# Altium schematic sheet 1541 Interface for ZX-81.SchDoc("1541 Interface for ZX-81")
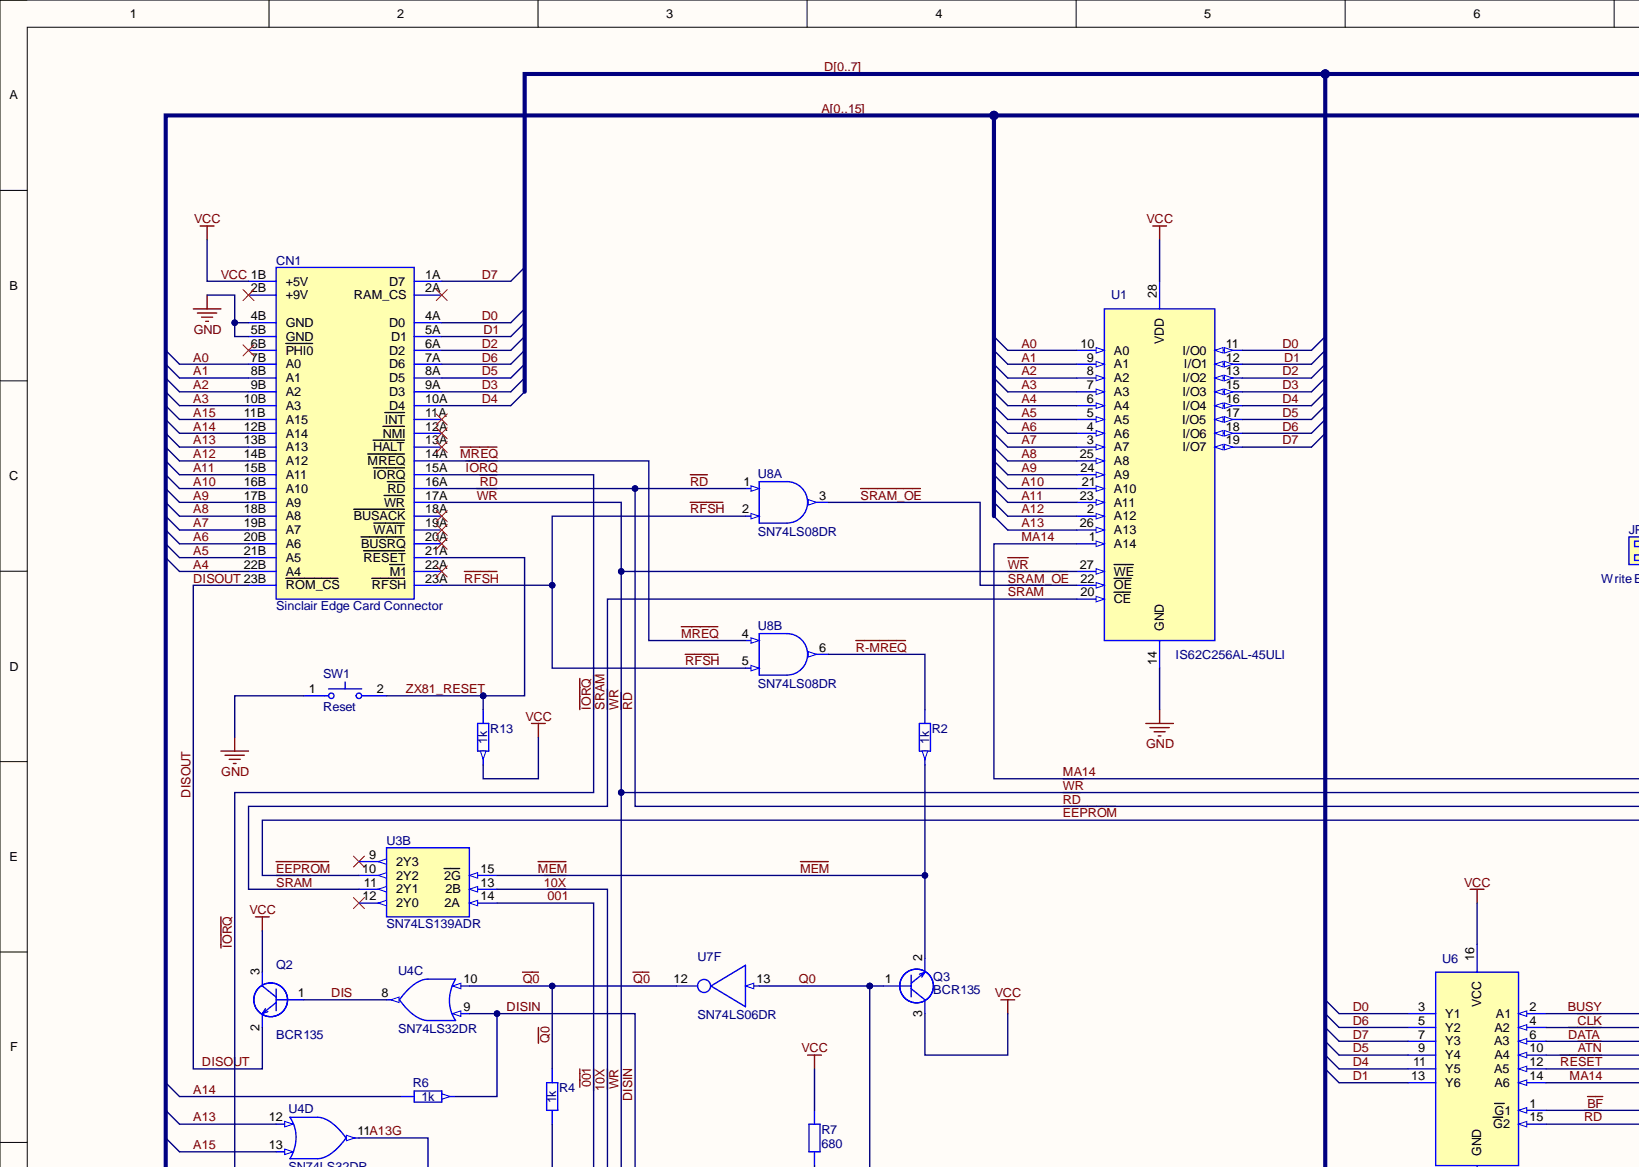
[diagram p0: 1541 Interface for ZX-81.SchDoc, top left]
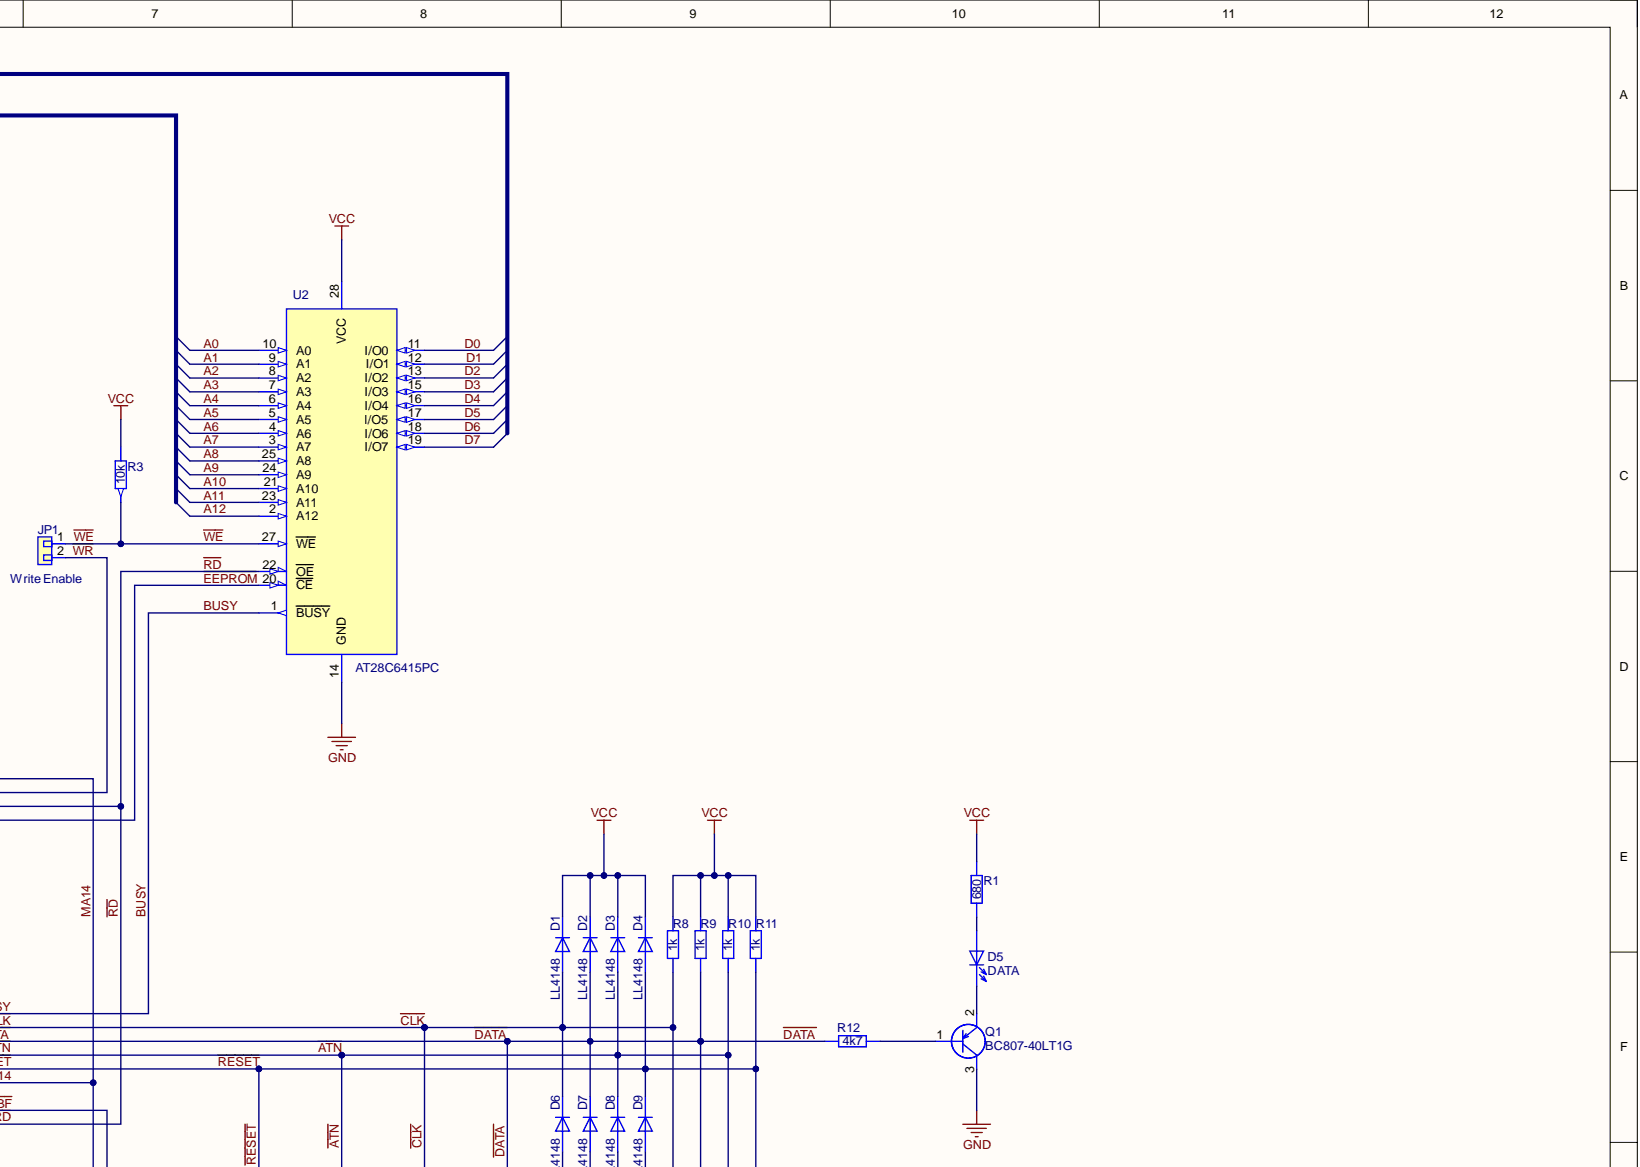
[diagram p1: 1541 Interface for ZX-81.SchDoc, top right]
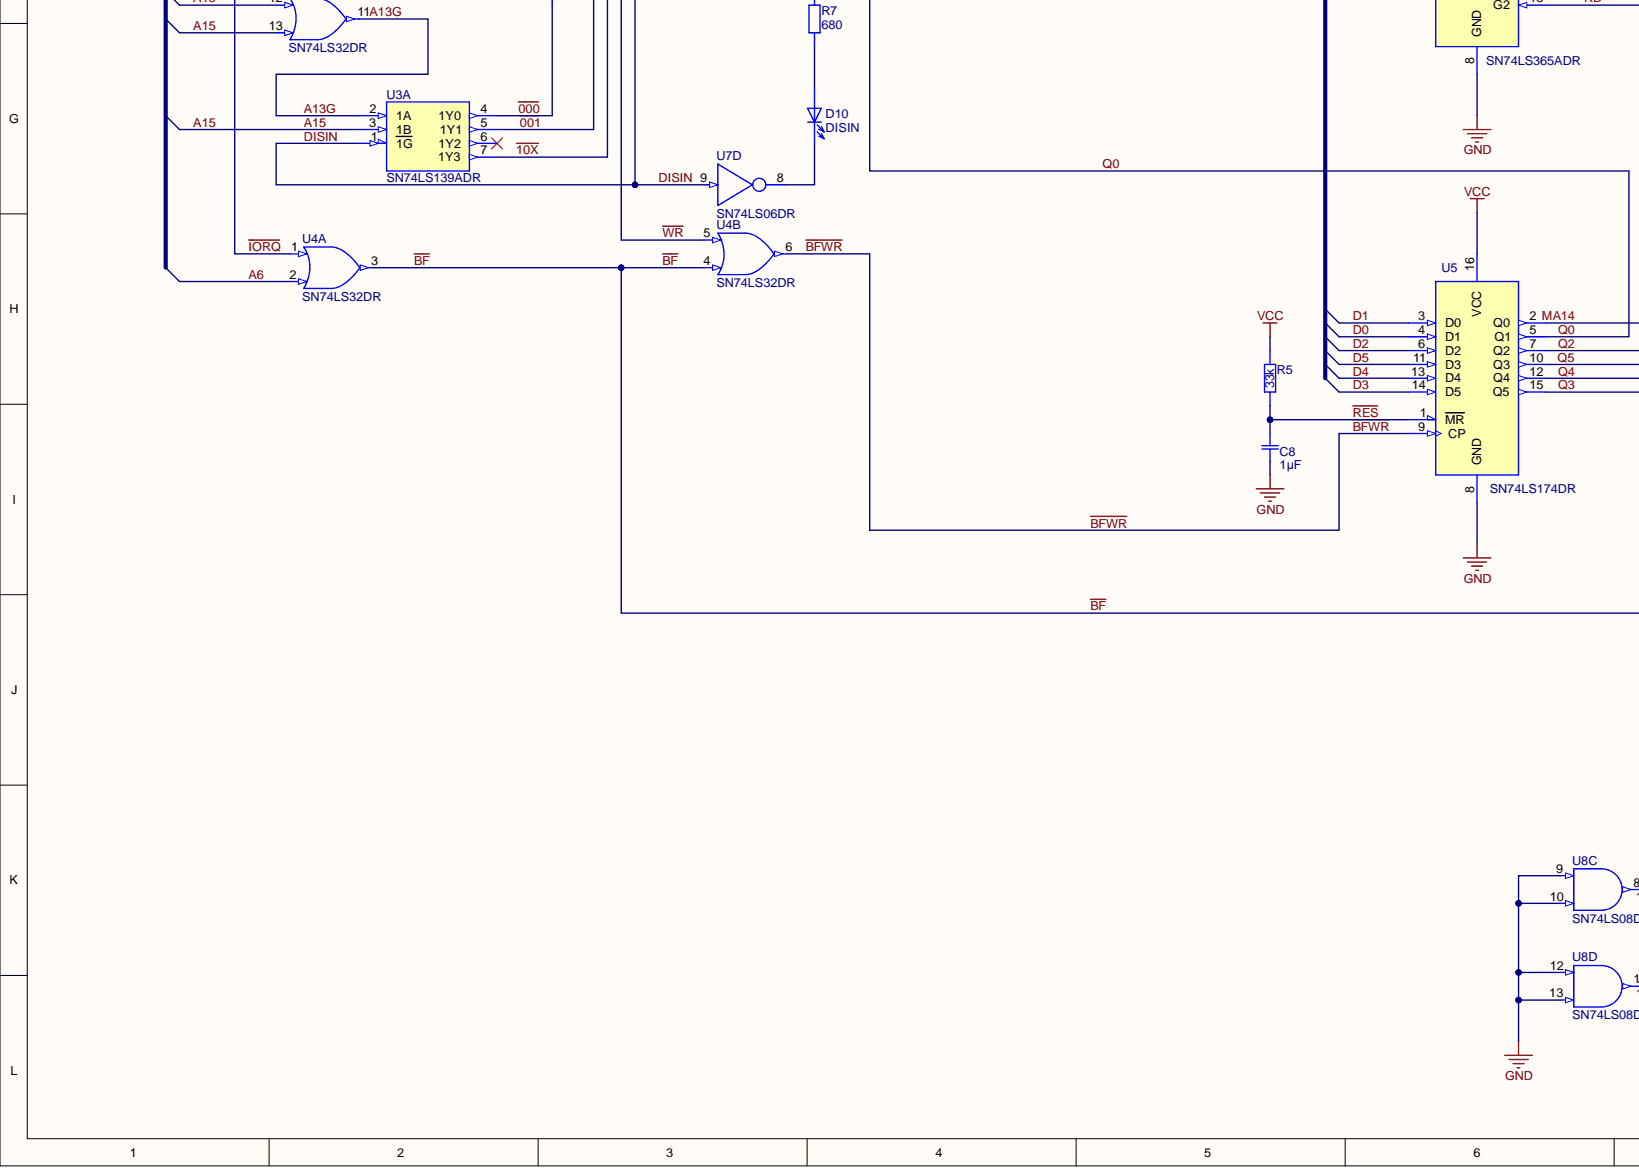
[diagram p2: 1541 Interface for ZX-81.SchDoc, bottom left]
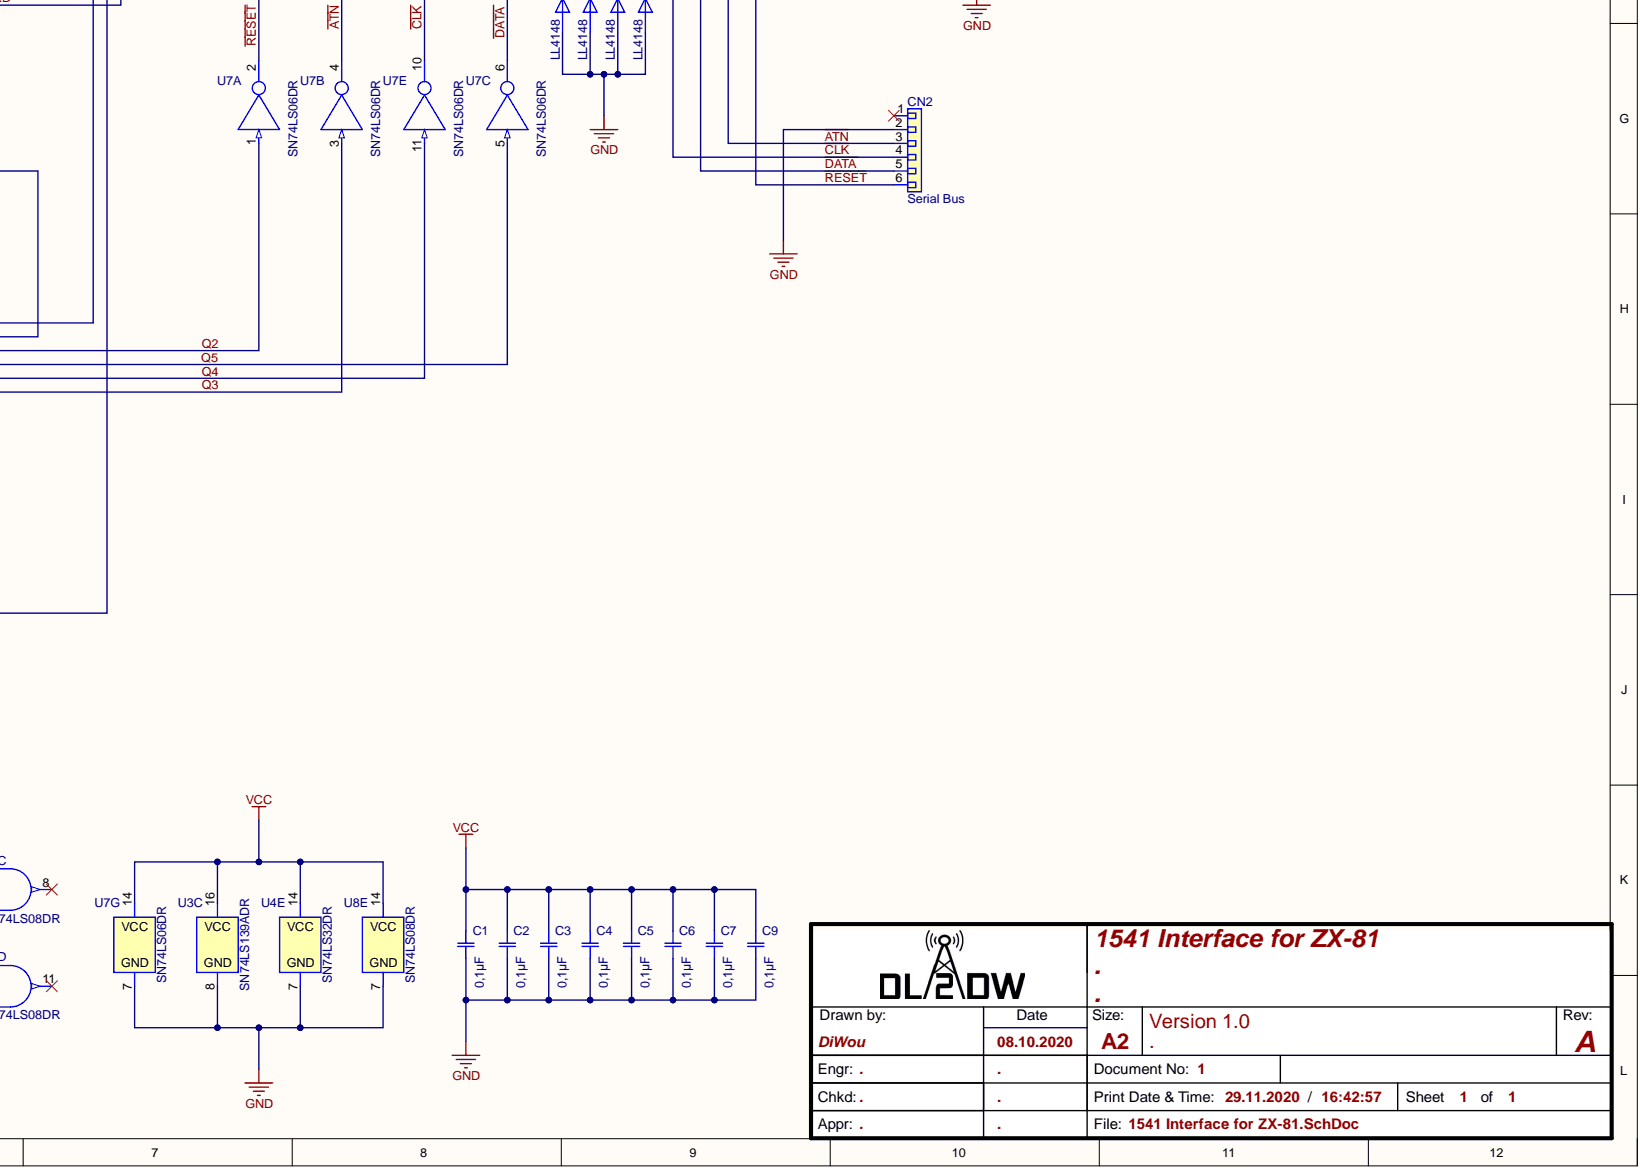
[diagram p3: 1541 Interface for ZX-81.SchDoc, bottom right]

## Parts
C1  0,1µF  [CAP SMD 0.1µF 50V 0805 Kemet C0805C104M5RACTU]
C2  0,1µF  [CAP SMD 0.1µF 50V 0805 Kemet C0805C104M5RACTU]
C3  0,1µF  [CAP SMD 0.1µF 50V 0805 Kemet C0805C104M5RACTU]
C4  0,1µF  [CAP SMD 0.1µF 50V 0805 Kemet C0805C104M5RACTU]
C5  0,1µF  [CAP SMD 0.1µF 50V 0805 Kemet C0805C104M5RACTU]
C6  0,1µF  [CAP SMD 0.1µF 50V 0805 Kemet C0805C104M5RACTU]
C7  0,1µF  [CAP SMD 0.1µF 50V 0805 Kemet C0805C104M5RACTU]
C8  1µF  [CAP 1µF 16V 0805 Kemet C0805C105K4RACTU]
C9  0,1µF  [CAP SMD 0.1µF 50V 0805 Kemet C0805C104M5RACTU]
CN1  Sinclair Edge Card Connector  [Sinclair Card Connector]
CN2  Serial Bus  [Pin Header 01x06 Right Angle RM2.54mm L5.08mm]
D1  LL4148  [MiniMELF Diode]
D2  LL4148  [MiniMELF Diode]
D3  LL4148  [MiniMELF Diode]
D4  LL4148  [MiniMELF Diode]
D5  DATA  [LED 3mm Red Right Angle 4mm]
D6  LL4148  [MiniMELF Diode]
D7  LL4148  [MiniMELF Diode]
D8  LL4148  [MiniMELF Diode]
D9  LL4148  [MiniMELF Diode]
D10  DISIN  [LED 3mm Green Right Angle 4mm]
JP1  Write Enable  [Pin Header 01x02 2.54mm Horizontal Male]
Q1  BC807-40LT1G  [Nexperia BC807-40 SOT23]
Q2  BCR135  [BCR135 SOT23]
Q3  BCR135  [BCR135 SOT23]
R1  680  [680 kOhm R 0805 SMD]
R2  1k  [1,0 kOhm R 0805 SMD]
R3  10k  [10 kOhm R 0805 SMD]
R4  1k  [1,0 kOhm R 0805 SMD]
R5  33k  [33 kOhm R 0805 SMD]
R6  1k  [1,0 kOhm R 0805 SMD]
R7  680  [680 kOhm R 0805 SMD]
R8  1k  [1,0 kOhm R 0805 SMD]
R9  1k  [1,0 kOhm R 0805 SMD]
R10  1k  [1,0 kOhm R 0805 SMD]
R11  1k  [1,0 kOhm R 0805 SMD]
R12  4k7  [4,7 kOhm R 0805 SMD]
R13  1k  [1,0 kOhm R 0805 SMD]
SW1  Reset  [Tactile Switch Push Button Right Angle L=8.35mm]
U1  IS62C256AL-45ULI  [IS62C256AL-45ULI 28L 330mil SOP]
U2  AT28C6415PC  Memory Type: EEPROM  [28C64-150 with Socket]
U3  SN74LS139ADR  [SN74LS139ADR SOIC-15 P1.27mm W3.9mm]  (parts A,B,C)
U4  SN74LS32DR  [SN74LS32DR2 SOIC-14 P1.27mm W3.9mm]  (parts A,B,C,D,E)
U5  SN74LS174DR  [SN74LS174DR SOIC-15 P1.27mm W3.9mm]
U6  SN74LS365ADR  [SN74LS365ADR SOIC-15 P1.27mm W3.9mm]
U7  SN74LS06DR  [SN74LS06DR SOIC-14 P1.27mm W3.9mm]  (parts A,B,C,D,E,F,G)
U8  SN74LS08DR  [SN74LS08DR SOIC-14 P1.27mm W3.9mm]  (parts A,B,C,D,E)

## Nets
GND: C1.1 C2.1 C3.1 C4.1 C5.1 C6.1 C7.1 C8.1 C9.1 CN1.4B(GND) CN1.5B(GND) CN2.2 D6.2 D7.2 D8.2 D9.2 Q1.3 SW1.1 U1.14(GND) U2.14(GND) U3.8 U4.7 U5.8(GND) U6.8(GND) U7.7 U8.7 U8.9 U8.10 U8.12 U8.13
~{000}: R4.1 U3.4(1Y0)
~{001}: U3.5(1Y1) U3.14(2A)
~{10X}: U3.7(1Y3) U3.13(2B)
A0: CN1.7B(A0) U1.10(A0) U2.10(A0)  [also labelled A]
A1: CN1.8B(A1) U1.9(A1) U2.9(A1)  [also labelled A]
A2: CN1.9B(A2) U1.8(A2) U2.8(A2)  [also labelled A]
A3: CN1.10B(A3) U1.7(A3) U2.7(A3)  [also labelled A]
A4: CN1.22B(A4) U1.6(A4) U2.6(A4)  [also labelled A]
A5: CN1.21B(A5) U1.5(A5) U2.5(A5)  [also labelled A]
A6: CN1.20B(A6) U1.4(A6) U2.4(A6) U4.2  [also labelled A]
A7: CN1.19B(A7) U1.3(A7) U2.3(A7)  [also labelled A]
A8: CN1.18B(A8) U1.25(A8) U2.25(A8)  [also labelled A]
A9: CN1.17B(A9) U1.24(A9) U2.24(A9)  [also labelled A]
A10: CN1.16B(A10) U1.21(A10) U2.21(A10)  [also labelled A]
A11: CN1.15B(A11) U1.23(A11) U2.23(A11)  [also labelled A]
A12: CN1.14B(A12) U1.2(A12) U2.2(A12)  [also labelled A]
A13: CN1.13B(A13) U1.26(A13) U4.12  [also labelled A]
A13G: U3.2(1A) U4.11
A14: CN1.12B(A14) R6.1  [also labelled A]
A15: CN1.11B(A15) U3.3(1B) U4.13  [also labelled A]
~{ATN}: CN2.3 D3.2 D8.1 R10.1 U6.10(A4) U7.4
~{BF}: U4.3 U4.4 U6.1(G1)
~{BFWR}: U4.6 U5.9(CP)
BUSY: U2.1(BUSY) U6.2(A1)
~{CLK}: CN2.4 D1.2 D6.1 R8.1 U6.4(A2) U7.10
D0: CN1.4A(D0) U1.11(I/O0) U2.11(I/O0) U5.4(D1) U6.3(Y1)  [also labelled D]
D1: CN1.5A(D1) U1.12(I/O1) U2.12(I/O1) U5.3(D0) U6.13(Y6)  [also labelled D]
D2: CN1.6A(D2) U1.13(I/O2) U2.13(I/O2) U5.6(D2)  [also labelled D]
D3: CN1.9A(D3) U1.15(I/O3) U2.15(I/O3) U5.14(D5)  [also labelled D]
D4: CN1.10A(D4) U1.16(I/O4) U2.16(I/O4) U5.13(D4) U6.11(Y5)  [also labelled D]
D5: CN1.8A(D5) U1.17(I/O5) U2.17(I/O5) U5.11(D3) U6.9(Y4)  [also labelled D]
D6: CN1.7A(D6) U1.18(I/O6) U2.18(I/O6) U6.5(Y2)  [also labelled D]
D7: CN1.1A(D7) U1.19(I/O7) U2.19(I/O7) U6.7(Y3)  [also labelled D]
~{DATA}: CN2.5 D2.2 D7.1 R9.1 R12.1 U6.6(A3) U7.6
DIS: Q2.1 U4.8
DISIN: R6.2 U3.1(1G) U4.9 U7.9
DISOUT: CN1.23B(ROM_CS) Q2.2
~{EEPROM}: U2.20(CE) U3.10(2Y2)
~{IORQ}: CN1.15A(IORQ) U4.1
~{MEM}: Q3.2 R2.2 U3.15(2G)
~{MREQ}: CN1.14A(MREQ) U8.4
MA14: U1.1(A14) U5.2(Q0) U6.14(A6)
Q0: Q3.1 U5.5(Q1) U7.13
Q2: U5.7(Q2) U7.1
Q3: U5.15(Q5) U7.3
Q4: U5.12(Q4) U7.11
Q5: U5.10(Q3) U7.5
~{Q0}: R4.2 U4.10 U7.12
~{R-MREQ}: R2.1 U8.6
~{RD}: CN1.16A(RD) U2.22(OE) U6.15(G2) U8.1
~{RES}: C8.2 R5.1 U5.1(MR)
~{RESET}: CN2.6 D4.2 D9.1 R11.1 U6.12(A5) U7.2
~{RFSH}: CN1.23A(RFSH) U8.2 U8.5
~{SRAM}: U1.20(CE) U3.11(2Y1)
~{SRAM_OE}: U1.22(OE) U8.3
VCC: C1.2 C2.2 C3.2 C4.2 C5.2 C6.2 C7.2 C9.2 CN1.1B(+5V) D1.1 D2.1 D3.1 D4.1 Q2.3 Q3.3 R1.2 R3.1 R5.2 R7.2 R8.2 R9.2 R10.2 R11.2 R13.2 U1.28(VDD) U2.28(VCC) U3.16 U4.14 U5.16(VCC) U6.16(VCC) U7.14 U8.14
~{WE}: JP1.1 R3.2 U2.27(WE)
~{WR}: CN1.17A(WR) JP1.2 U1.27(WE) U4.5
ZX81_RESET: CN1.21A(RESET) R13.1 SW1.2
NetD5_1: D5.1 Q1.2
NetD5_2: D5.2 R1.1
NetD10_1: D10.1 U7.8
NetD10_2: D10.2 R7.1
NetQ1_1: Q1.1 R12.2

Not connected: CN1 2A(RAM_CS) 2B(+9V) 6B(PHI0) 11A(INT) 12A(NMI) 13A(HALT) 18A(BUSACK) 19A(WAIT) 20A(BUSRQ) 22A(M1); CN2 1; U3 6(1Y2) 9(2Y3) 12(2Y0); U8 8 11

## Library data of the parts
C1-C7 C9 = CMP-Capacitors-Ceramic_Capacitors-00028-1: Capacitor; Ceramic; Cap; .100uF; Tol 20%; SMT; Vol-Rtg 50V; X7R; Tape and Reel; Capacitance: 100nF; Case/Package: 0805; Component Kind: Standard; Depth: 1.25mm; Dielectric: X7R; Dielectric Material: Ceramic; Height: 0.9mm; Length: 2mm; Library Name: PCB-Tech Cloud; Max Operating Temperature: 125°C; Min Operating Temperature: -55°C; Mount: Surface Mount; Packaging: Tape and Reel; Radiation Hardening: No; Tolerance: 20%; Voltage Rating (DC): 50V
C8 = CMP-Capacitors-Ceramic_Capacitors-00042-2: KEMET - C0805C105K4RACTU - SMD Multilayer Ceramic Capacitor, 1 µF, 16 V, 0805 [2012 Metric], ± 10%, X7R, C Series KEMET; Capacitance: 1uF; Dissipation Factor: 5%; Voltage: 16V; Case Code (Imperial): 0805; Case Code (Metric): 2012; Case/Package: 0805; Component Kind: Standard; Contact Plating: Tin,Matte; Depth: 1.25mm; Dielectric: X7R; Dielectric Material: Ceramic; Height: 0.9mm; Lead Pitch: 0.75mm; Length: 2mm; Library Name: PCB-Tech Cloud; Max Operating Temperature: 125°C; Min Operating Temperature: -55°C; Mount: Surface Mount,PCB; Number of Pins: 2; Package Quantity: 1; Packaging: Tape and Reel; Radiation Hardening: No; REACH SVHC: No SVHC; Termination: SMD/SMT; Thickness: 0.039inch; Tolerance: 10%; Voltage Rating: 16V; Voltage Rating (DC): 16V; Width: 0.049inch
CN1 = CMP-Connectors-00027-6: Component Kind: Standard; Description: Sinclair Edge Card Connector; Library Name: PCB-Tech Cloud
CN2 = CMP-Connectors-Male_PIN_Headers-00025-2: CONN HEADER R/A 6POS 2.54MM; Connector Type: Male Pin; Number of Positions: 6; Pitch: 0.1inch; Color: Black; Component Kind: Standard; Contact Mating Length: 0.23inch; Contact Plating: Gold; Library Name: PCB-Tech Cloud; Mount: Through Hole; Number of Rows: 1; Package Quantity: 1; Packaging: Bulk; Termination: Solder
D1-D4 D6-D9 = CMP-Diodes-00018-2: ON SEMICONDUCTOR - LL4148 - Small Signal Diode, Single, 100 V, 200 mA, 1 V, 4 ns, 2 A; Average Rectified Current: 200mA; Forward Voltage: 1V; Max Forward Surge Current (Ifsm): 2A; Max Repetitive Reverse Voltage (Vrrm): 100V; Max Reverse Current: 25nA; Power Dissipation: 500mW; Recovery Time: 4ns; Component Kind: Standard; Contact Plating: Tin,Matte; Element Configuration: Single; Forward Current: 200mA; Height: 1.5mm; Lead Free: Lead Free; Length: 3.6mm; Library Name: PCB-Tech Cloud; Max Operating Temperature: 175°C; Max Reverse Voltage (DC): 100V; Max Surge Current: 2A; Min Operating Temperature: -65°C; Mount: Surface Mount; Number of Pins: 2; Package Quantity: 2500; Packaging: Tape and Reel; Peak Non-Repetitive Surge Current: 2A; Radiation Hardening: No; REACH SVHC: No SVHC; Reverse Recovery Time: 4ns; Reverse Voltage: 100V; Voltage: 100V; Weight: 0.01lb; Width: 1.5mm
D5 = CMP-LEDs-00000-4: WURTH ELEKTRONIK   151033RS03000   LED, 3MM,  RED, 2600MCD, 621NM; Forward Current: 30mA; Forward Voltage: 2V; Lens Style: Clear,Circular,Round; Test Current: 20mA; Viewing Angle: 30°; Case/Package: Radial; Color: Red; Component Kind: Standard; Dominant Wavelength: 621nm; Height: 5.55mm; Lens Size: 3mm; Library Name: PCB-Tech Cloud; Luminous Intensity: 2600mcd; Max Operating Temperature: 85°C; Min Operating Temperature: -40°C; Mount: Through Hole; Package Quantity: 1; Packaging: Bulk; REACH SVHC: Unknown; Wavelength: 621nm
D10 = CMP-LEDs-00007-1: WURTH ELEKTRONIK - 151033GS03000 - LED, Green, T-1 (3mm), 530 nm, 3.2 V, 30 mA, 15 cd RoHS Compliant: Yes; Dominant Wavelength: 530nm; Forward Current: 30mA; Forward Voltage: 3.2V; Lens Style: Clear,Round,Circular; Luminous Intensity: 15000mcd; Test Current: 20mA; Viewing Angle: 30°; Case/Package: Radial; Color: Green; Component Kind: Standard; Height: 5.55mm; Lens Size: 3mm; Library Name: PCB-Tech Cloud; Max Operating Temperature: 85°C; Min Operating Temperature: -40°C; Mount: Through Hole; Package Quantity: 1; Packaging: Bulk; REACH SVHC: Unknown; Wavelength: 530nm
JP1 = CMP-Connectors-Male_PIN_Headers-00001-4: CONN HEADER .100" SNGL STR 2POS; Connector Type: Male Pin; Number of Positions: 2; Pitch: 0.1inch; Color: Black; Component Kind: Standard; Contact Mating Length: 0.318inch; Contact Plating: Gold; Library Name: PCB-Tech Cloud; Mount: Through Hole; Number of Rows: 1; Package Quantity: 1; Packaging: Bulk; Termination: Solder
Q1 = CMP-Transistors-00011-1: Silicon Transistor Plastic,  BC807-40LT1G; Collector Base Voltage (VCBO): 50V; Collector Emitter Breakdown Voltage: 45V; Collector Emitter Saturation Voltage: -700mV; Element Configuration: Single; Emitter Base Voltage (VEBO): 5V; Frequency: 100MHz; hFE Min: 250; Max Collector Current: 500mA; Max Power Dissipation: 300mW; Number of Elements: 1; Polarity: PNP; Case/Package: SOT-23-3; Collector Emitter Voltage (VCEO): 45V; Component Kind: Standard; Contact Plating: Tin,Matte; Gain Bandwidth Product: 100MHz; Halogen Free: Halogen Free; Height: 0.94mm; Lead Free: Lead Free; Length: 2.9mm; Library Name: PCB-Tech Cloud; Max Breakdown Voltage: 45V; Max Frequency: 100MHz; Max Operating Temperature: 150°C; Min Operating Temperature: -55°C; Number of Pins: 3; Package Quantity: 1; Packaging: Tape and Reel; Power Dissipation: 300mW; Radiation Hardening: No; REACH SVHC: No SVHC; Transition Frequency: 100MHz; Width: 1.3mm
Q2 Q3 = CMP-Transistors-00010-1: BCR135 Series NPN 50 V 100 mA SMT Silicon Digital Transistor - SOT-23-3; Collector Emitter Saturation Voltage: 300mV; Collector Emitter Voltage (VCEO): 50V; Element Configuration: Single; hFE Min: 70; Max Collector Current: 100mA; Power Dissipation: 200mW; Case/Package: SOT; Component Kind: Standard; Height: 1mm; Length: 2.9mm; Library Name: PCB-Tech Cloud; Max Operating Temperature: 150°C; Min Operating Temperature: -65°C; Number of Pins: 3; Packaging: Tape and Reel; Polarity: NPN; Width: 1.3mm
R1 R7 = CMP-Resistors-Yageo_SMD_0805-0140-1: RES SMD 680K OHM 1% 1/8W 0805; Max Power Dissipation: 125mW; Resistance: 680kR; Temperature Coefficient: 100ppm/°C; Voltage Rating: 150V; Case Code (Imperial): 0805; Case Code (Metric): 2012; Case/Package: 0805; Component Kind: Standard; Composition: Thick Film; Contact Plating: Tin,Matte; Depth: 1.25mm; Features: Moisture Resistant; Height: 0.024inch; Lead Free: Lead Free; Length: 0.079inch; Library Name: PCB-Tech Cloud; Max Operating Temperature: 155°C; Military Standard: Not; Min Operating Temperature: -55°C; Number of Terminations: 2; Package Quantity: 1; Packaging: Tape and Reel; Power Rating: 125mW; Radiation Hardening: No; REACH SVHC: Unknown; Termination: SMD/SMT; Tolerance: 1%; Width: 0.049inch
R2 R4 R6 R8-R11 R13 = CMP-Resistors-Yageo_SMD_0805-0072-1: RES SMD 1K OHM 1% 1/8W 0805; Max Power Dissipation: 125mW; Resistance: 1kR; Temperature Coefficient: 100ppm/°C; Voltage Rating: 150V; Case Code (Imperial): 0805; Case Code (Metric): 2012; Case/Package: 0805; Component Kind: Standard; Composition: Thick Film; Depth: 1.25mm; Features: Moisture Resistant; Height: 0.024inch; Lead Free: Lead Free; Length: 0.079inch; Library Name: PCB-Tech Cloud; Max Operating Temperature: 155°C; Military Standard: Not; Min Operating Temperature: -55°C; Number of Pins: 2; Number of Terminations: 2; Package Quantity: 1; Packaging: Tape and Reel; Power Rating: 125mW; REACH SVHC: Unknown; Termination: SMD/SMT; Tolerance: 1%; Voltage Rating (DC): 150V; Width: 0.049inch
R3 = CMP-Resistors-Yageo_SMD_0805-0096-1: RES SMD 10K OHM 1% 1/8W 0805; Max Power Dissipation: 125mW; Resistance: 10kR; Temperature Coefficient: 100ppm/°C; Voltage Rating: 150V; Case Code (Imperial): 0805; Case Code (Metric): 2012; Case/Package: 0805; Component Kind: Standard; Composition: Thick Film; Depth: 1.25mm; Features: Moisture Resistant; Height: 0.024inch; Lead Free: Lead Free; Length: 2mm; Library Name: PCB-Tech Cloud; Max Operating Temperature: 155°C; Military Standard: Not; Min Operating Temperature: -55°C; Number of Terminations: 2; Package Quantity: 1; Packaging: Tape and Reel; Power Rating: 125mW; REACH SVHC: Unknown; Termination: SMD/SMT; Tolerance: 1%; Width: 0.049inch
R5 = CMP-Resistors-Yageo_SMD_0805-0108-1: RES SMD 33K OHM 1% 1/8W 0805; Max Power Dissipation: 125mW; Resistance: 33kR; Temperature Coefficient: 100ppm/°C; Voltage Rating: 150V; Case Code (Imperial): 0805; Case Code (Metric): 2012; Case/Package: 0805; Component Kind: Standard; Composition: Thick Film; Depth: 1.25mm; Features: Moisture Resistant; Height: 0.024inch; Lead Free: Lead Free; Length: 0.079inch; Library Name: PCB-Tech Cloud; Max Operating Temperature: 155°C; Min Operating Temperature: -55°C; Number of Terminations: 2; Package Quantity: 1; Packaging: Tape and Reel; Power Rating: 125mW; REACH SVHC: Unknown; Termination: SMD/SMT; Tolerance: 1%; Width: 0.049inch; Working Voltage: 150V
R12 = CMP-Resistors-Yageo_SMD_0805-0087-1: YAGEO (PHYCOMP)         RC0805FR-074K7L             SMD Chip Resistor, Thick Film, 4.7 kohm, 150 V, 0805 [2012 Metric], 250 mW,  1%; Max Power Dissipation: 125mW; Resistance: 4.7kR; Temperature Coefficient: 100ppm/°C; Voltage Rating: 150V; Case Code (Imperial): 0805; Case Code (Metric): 2012; Case/Package: 0805; Component Kind: Standard; Composition: Thick Film; Depth: 1.25mm; Features: Moisture Resistant; Height: 0.024inch; Lead Free: Lead Free; Length: 0.079inch; Library Name: PCB-Tech Cloud; Max Operating Temperature: 155°C; Military Standard: Not; Min Operating Temperature: -55°C; Number of Pins: 2; Number of Terminations: 2; Package Quantity: 5000; Packaging: Tape and Reel; Power Rating: 125mW; REACH SVHC: No SVHC; Termination: SMD/SMT; Tolerance: 1%; Voltage Rating (DC): 150V; Width: 0.049inch
SW1 = CMP-Switches-00004-1: SWITCH TACTILE SPST-NO 0.05A 24V; Actuator Length: 0.226inch; Actuator Material: PBT; Actuator Type: Standard; Contact Current Rating: 50mA; Contact Resistance: 100mR; Electrical Life: 100000Cycles; Illumination Color: Black; Illumination Type: Non-Illuminated; Operating Force: 160gf; Switch Function: Off-Mom; Switch Travel: 0.25mm; Throw Configuration: SPST; Component Kind: Standard; Contact Material: Copper Alloy; Contact Plating: Silver; Depth: 12.36mm; ELV: Compliant; Height: 7.3mm; Lead Free: Lead Free; Length: 7.11mm; Library Name: PCB-Tech Cloud; Max Current Rating: 50mA; Max Operating Temperature: 85°C; Max Voltage Rating (DC): 24V; Mechanical Life: 100000Cycles; Min Operating Temperature: -35°C; Mount: Through Hole; Orientation: Straight; Package Quantity: 1000; Packaging: Loose Piece; Radiation Hardening: No; Termination: PC Pins; Type: Switch; Width: 6mm
U1 = CMP-ICs-Memory-00012-3: SRAM Chip Async Single 5V 256K-Bit 32K x 8 45ns 28-Pin SOP; Address Bus Width: 15b; Density: 256Kb; Interface: Parallel; Max Frequency: 22MHz; Max Supply Voltage: 5.5V; Memory Type: RAM,SRAM - Asynchronous; Min Supply Voltage: 4.5V; Nominal Supply Current: 25mA; Number of Ports: 1; Number of Words: 32000; Sync/Async: Asynchronous; Word Size: 8b; Access Time: 45ns; Case/Package: SOP; Component Kind: Standard; Height: 2.84mm; Lead Free: Lead Free; Length: 18.24mm; Library Name: PCB-Tech Cloud; Max Operating Temperature: 85°C; Memory Size: 256Kb; Min Operating Temperature: -40°C; Number of Pins: 28; Operating Supply Voltage: 5V; Package Quantity: 120; Packaging: Rail/Tube; Radiation Hardening: No; REACH SVHC: No SVHC; Voltage: 5V; Width: 8.53mm
U2 = CMP-ICs-Memory-00010-2: IC EEPROM 64KBIT 150NS 28DIP; Density: 64Kb; Frequency: 150GHz; Interface: Parallel; Max Supply Voltage: 5.5V; Min Supply Voltage: 4.5V; Nominal Supply Current: 30mA; Access Time: 150ns; Case/Package: PDIP; Component Kind: Standard; Lead Free: Contains Lead; Library Name: PCB-Tech Cloud; Max Operating Temperature: 70°C; Memory Size: 64Kb; Min Operating Temperature: 0°C; Mount: Through Hole; Number of Pins: 28; Package Quantity: 14; Packaging: Rail/Tube
U3 = CMP-ICs-74xx-series-00066-1: Decoder/Demultiplexer Dual 2-to-4 16-Pin SOIC T/R; Bandwidth: 35MHz; High Level Output Current: -400uA; Independent Circuits: 2; Logic Function: Demultiplexer,Decoder; Low Level Output Current: 8mA; Max Supply Voltage: 5.25V; Min Supply Voltage: 4.75V; Number of Bits: 4; Operating Supply Voltage: 5V; Polarity: Inverting; Propagation Delay: 33ns; Supply Type: Single; Turn-On Delay Time: 38ns; Case/Package: SOIC; Component Kind: Standard; Lead Free: Lead Free; Library Name: PCB-Tech Cloud; Max Operating Temperature: 70°C; Min Operating Temperature: 0°C; Mount: Surface Mount; Number of Channels: 2; Number of Elements: 2; Number of Input Lines: 2; Number of Inputs: 2; Number of Output Lines: 4; Number of Outputs: 4; Number of Pins: 16; Package Quantity: 2500; Packaging: Tape and Reel; Radiation Hardening: No; Weight: 0.004998oz
U4 = CMP-ICs-74xx-series-00065-1: IC GATE OR 4CH 2-INP 14SOIC; High Level Output Current: -16mA; Logic Function: OR GATE; Low Level Output Current: 800uA; Max Supply Voltage: 5.25V; Min Supply Voltage: 4.75V; Number of Bits: 4; Number of Input Lines: 2; Number of Output Lines: 1; Operating Supply Voltage: 5V; Output Current: 8mA; Output Type: TTL; Propagation Delay: 22ns; Quiescent Current: 4.9mA; Case/Package: SOIC; Component Kind: Standard; Lead Free: Lead Free; Library Name: PCB-Tech Cloud; Max Operating Temperature: 70°C; Min Operating Temperature: 0°C; Mount: Surface Mount; Number of Circuits: 4; Number of Elements: 4; Number of Gates: 4; Number of Inputs: 2; Number of Outputs: 1; Number of Pins: 14; Package Quantity: 2500; Packaging: Tape and Reel; Radiation Hardening: No; REACH SVHC: No SVHC; Turn-On Delay Time: 22ns; Weight: 0.004564oz
U5 = CMP-ICs-74xx-series-00067-1: Flip Flops Hex; Clock Edge Trigger Type: Positive Edge; Frequency: 40MHz; High Level Output Current: -400uA; Logic Function: D-Type,Flip-Flop; Low Level Output Current: 8mA; Max Supply Voltage: 5.25V; Min Supply Voltage: 4.75V; Number of Bits: 6; Number of Circuits: 7; Number of Elements: 1; Number of Input Lines: 2; Operating Supply Voltage: 5V; Output Type: TTL; Polarity: Non-Inverting; Propagation Delay: 30ns; Turn-On Delay Time: 35ns; Case/Package: SOIC; Component Kind: Standard; Lead Free: Lead Free; Library Name: PCB-Tech Cloud; Max Operating Temperature: 70°C; Min Operating Temperature: 0°C; Mount: Surface Mount; Number of Bits per Element: 6; Number of Output Lines: 2; Number of Pins: 16; Output Current: 8mA; Package Quantity: 2500; Packaging: Tape and Reel; Radiation Hardening: No; Weight: 0.004998oz
U6 = CMP-ICs-74xx-series-00068-1: IC BUFF/DVR TRI-ST 6BIT 16SOIC; High Level Output Current: -2.6mA; Logic Function: Buffer,Inverting; Low Level Output Current: 24mA; Max Supply Voltage: 5.25V; Min Supply Voltage: 4.75V; Number of Bits: 6; Number of Elements: 1; Operating Supply Voltage: 5V; Polarity: Non-Inverting; Propagation Delay: 22ns; Case/Package: SOIC; Component Kind: Standard; Contact Plating: Gold; Lead Free: Lead Free; Library Name: PCB-Tech Cloud; Max Operating Temperature: 70°C; Min Operating Temperature: 0°C; Mount: Surface Mount; Number of Bits per Element: 6; Number of Input Lines: 6; Number of Output Lines: 6; Number of Outputs: 6; Number of Pins: 16; Package Quantity: 2500; Packaging: Tape and Reel; Radiation Hardening: No
U7 = CMP-ICs-74xx-series-00051-2: IC INVERTER OPEN COL 6CH 14SOIC; High Level Output Current: -250uA; Logic Function: Buffer,Inverter; Low Level Output Current: 40mA; Max Supply Voltage: 5.25V; Min Supply Voltage: 4.75V; Number of Bits: 6; Operating Supply Voltage: 5V; Polarity: Inverting; Propagation Delay: 20ns; Case/Package: SOIC; Component Kind: Standard; Contact Plating: Gold; Lead Free: Lead Free; Library Name: PCB-Tech Cloud; Max Operating Temperature: 70°C; Min Operating Temperature: 0°C; Mount: Surface Mount; Number of Channels: 6; Number of Circuits: 6; Number of Elements: 6; Number of Gates: 6; Number of Input Lines: 6; Number of Inputs: 6; Number of Output Lines: 6; Number of Outputs: 6; Number of Pins: 14; Package Quantity: 1; Packaging: Tape and Reel; Radiation Hardening: No; REACH SVHC: No SVHC; Turn-On Delay Time: 20ns
U8 = CMP-ICs-74xx-series-00069-1: TEXAS INSTRUMENTS         SN74LS08DR             AND Gate, 8 Input, 4 Gate, 8 mA, 4.75V to 5.25V, SOIC-14; High Level Output Current: -800uA; Low Level Output Current: 16mA; Number of Bits: 4; Number of Input Lines: 2; Number of Output Lines: 1; Operating Supply Voltage: 5V; Output Current: 8mA; Propagation Delay: 20ns; Quiescent Current: 4.4mA; Turn-On Delay Time: 25ns; Case/Package: SOIC; Component Kind: Standard; Contact Plating: Gold; Lead Free: Lead Free; Library Name: PCB-Tech Cloud; Logic Function: AND GATE; Max Operating Temperature: 70°C; Max Supply Voltage: 5.25V; Min Operating Temperature: 0°C; Min Supply Voltage: 4.75V; Mount: Surface Mount; Number of Circuits: 4; Number of Elements: 4; Number of Gates: 4; Number of Inputs: 2; Number of Outputs: 1; Number of Pins: 14; Package Quantity: 2500; Packaging: Tape and Reel; Radiation Hardening: No; REACH SVHC: No SVHC; Weight: 0.004564oz
